# Altium schematic sheet Memory-CAN.SchDoc(Memory-CAN)
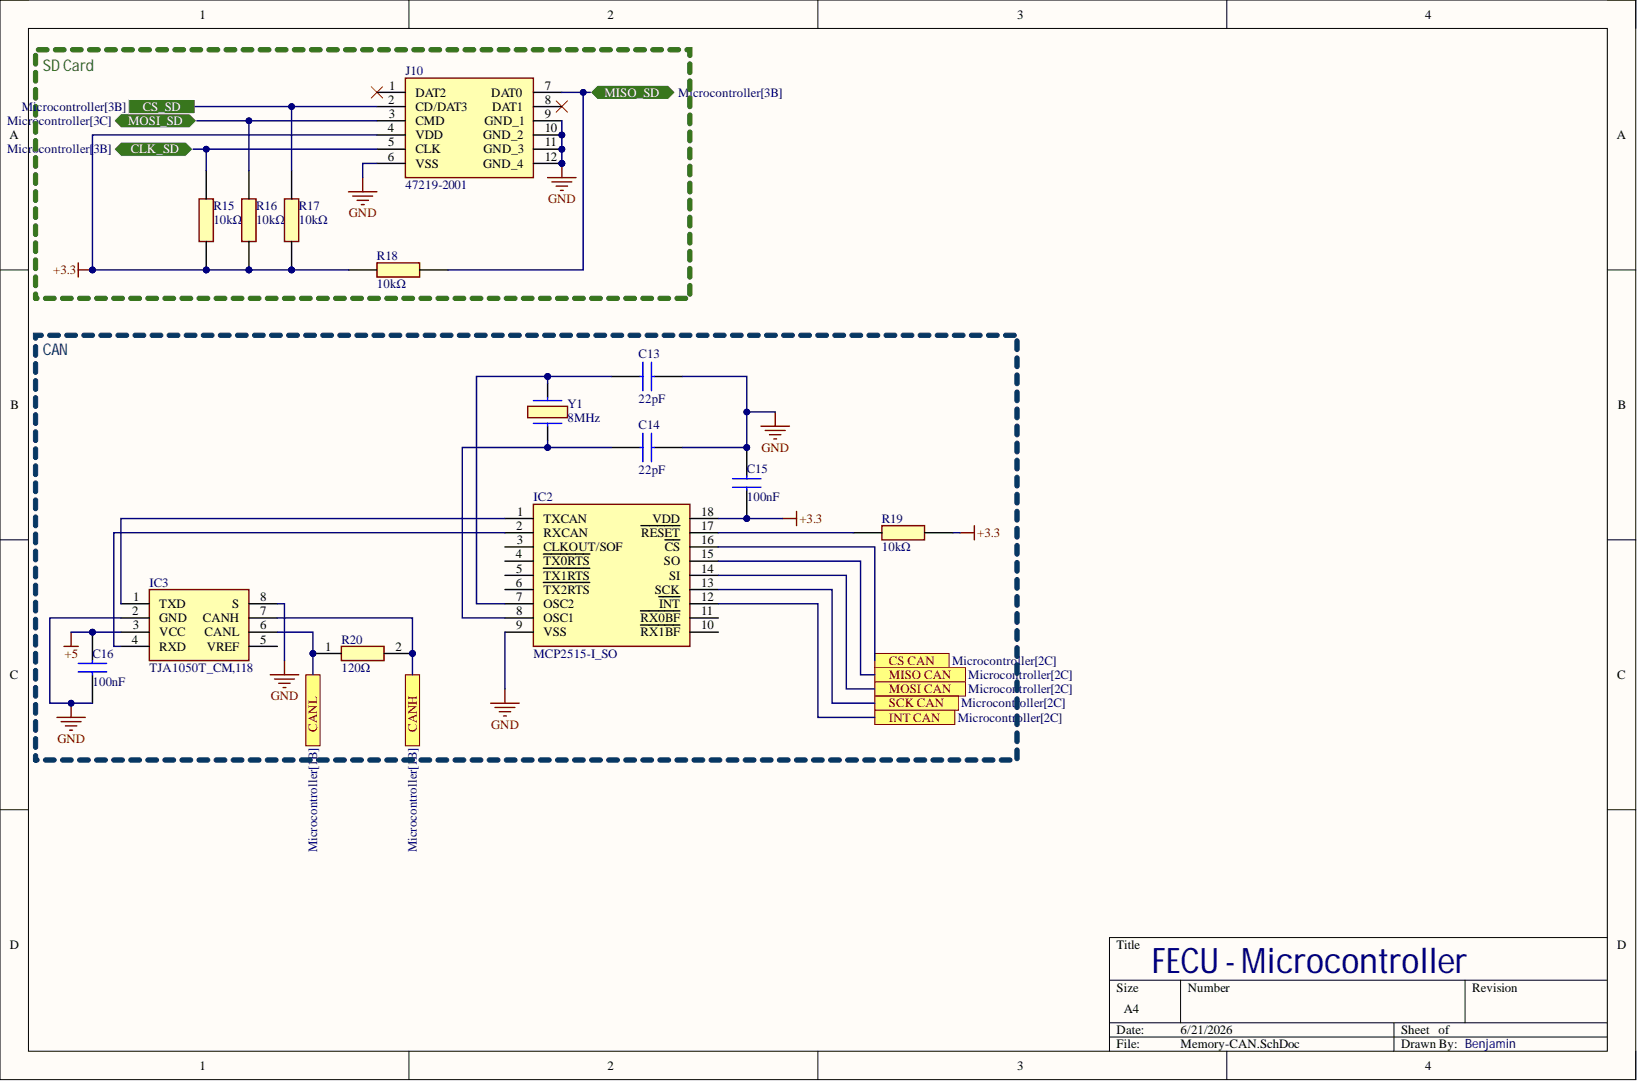
[diagram p0: Memory-CAN.SchDoc]

## Parts
C13  22pF
C14  22pF
C15  100nF
C16  100nF
IC2  MCP2515-I_SO
IC3  TJA1050T_CM,118
J10  47219-2001
R15  10kΩ
R16  10kΩ
R17  10kΩ
R18  10kΩ
R19  10kΩ
R20  120Ω
Y1  8MHz

## Ports
CANH, CANL, CLK_SD, CS CAN, CS_SD, INT CAN, MISO CAN, MISO_SD, MOSI CAN, MOSI_SD, SCK CAN

## Nets
+3.3: C15.2 IC2.18(VDD) J10.4(VDD) R15.1 R16.1 R17.1 R18.1 R19.2
+5: C16.1 IC3.3(VCC)
GND: C13.1 C14.1 C15.1 C16.2 IC2.9(VSS) IC3.2(GND) IC3.8(S) J10.6(VSS) J10.9(GND_1) J10.10(GND_2) J10.11(GND_3) J10.12(GND_4)
CANH: IC3.7(CANH) R20.2 ; port CANH
CANL: IC3.6(CANL) R20.1 ; port CANL
CLK_SD: J10.5(CLK) R15.2 ; port CLK_SD
CS CAN: IC2.16(CS) ; port CS CAN
CS_SD: J10.2(CD/DAT3) R17.2 ; port CS_SD
INT CAN: IC2.12(INT) ; port INT CAN
MISO CAN: IC2.15(SO) ; port MISO CAN
MISO_SD: J10.7(DAT0) R18.2 ; port MISO_SD
MOSI CAN: IC2.14(SI) ; port MOSI CAN
MOSI_SD: J10.3(CMD) R16.2 ; port MOSI_SD
SCK CAN: IC2.13(SCK) ; port SCK CAN
NetC13_2: C13.2 IC2.7(OSC2) Y1.
NetC14_2: C14.2 IC2.8(OSC1) Y1.2
NetIC2_1: IC2.1(TXCAN) IC3.1(TXD)
NetIC2_2: IC2.2(RXCAN) IC3.4(RXD)
NetIC2_17: IC2.17(RESET) R19.1

Not connected: IC2 3(CLKOUT/SOF) 4(TX0RTS) 5(TX1RTS) 6(TX2RTS) 10(RX1BF) 11(RX0BF); IC3 5(VREF); J10 1(DAT2) 8(DAT1)

## Library data of the parts
C13 C14 = 0805YA220JAT2A: Capacitor; Manufacturer_Name: Kyocera AVX; Component Kind: Standard; Manufacturer_Part_Number: 0805YA220JAT2A; Mouser Part Number: 581-0805YA220JAT2A; Mouser Price/Stock: https://www.mouser.co.uk/ProductDetail/KYOCERA-AVX/0805YA220JAT2A?qs=h45VL9SNQ1uLzsqNWWHBSQ%3D%3D
C15 C16 = CL21B104KBCNNNC: Capacitor; Manufacturer_Name: SAMSUNG; Arrow Part Number: CL21B104KBCNNNC; Arrow Price/Stock: https://www.arrow.com/en/products/cl21b104kbcnnnc/samsung-electro-mechanics?region=europe; Component Kind: Standard; Datasheet Link: https://datasheet.datasheetarchive.com/originals/distributors/Datasheets_SAMA/86a3eaf0882763ef32124d5901f9b833.pdf; Height: 0.95mm; Manufacturer_Part_Number: CL21B104KBCNNNC; Mouser Part Number: 187-CL21B104KBCNNNC; Mouser Price/Stock: https://www.mouser.co.uk/ProductDetail/Samsung-Electro-Mechanics/CL21B104KBCNNNC?qs=349EhDEZ59pEfpnm5yBEmQ%3D%3D
IC2 = MCP2515-I_SO: Integrated Circuit; Manufacturer_Name: Microchip; Manufacturer_Part_Number: MCP2515-I/SO; Arrow Part Number: MCP2515-I/SO; Arrow Price/Stock: https://www.arrow.com/en/products/mcp2515-iso/microchip-technology?utm_currency=USD&region=europe; Component Kind: Standard; Datasheet Link: https://datasheet.datasheetarchive.com/originals/distributors/Datasheets-34/DSA-675138.pdf; Height: 2.65mm; Mouser Part Number: 579-MCP2515-I/SO; Mouser Price/Stock: https://www.mouser.co.uk/ProductDetail/Microchip-Technology/MCP2515-I-SO?qs=KwArPi4cUogGDbGnphOvtQ%3D%3D
IC3 = TJA1050T_CM,118: Integrated Circuit; Manufacturer_Name: NXP; Manufacturer_Part_Number: TJA1050T/CM,118; Arrow Part Number: TJA1050T/CM,118; Arrow Price/Stock: https://www.arrow.com/en/products/tja1050tcm118/nxp-semiconductors?utm_currency=USD&region=nac; Component Kind: Standard; Datasheet Link: http://www.nxp.com/docs/en/data-sheet/TJA1050.pdf; Height: 1.75mm; Mouser Part Number: 771-TJA1050T/CM118; Mouser Price/Stock: https://www.mouser.co.uk/ProductDetail/NXP-Semiconductors/TJA1050T-CM118?qs=5XOdhvmYM0NFFspw0VP5sA%3D%3D
J10 = 47219-2001: Connector; Manufacturer_Name: Molex; Component Kind: Standard; Datasheet Link: http://www.molex.com/molex/products/datasheet.jsp?part=active/0472192001_MEMORY_CARD_SOCKET.xml&channel=Products&Lang=en-US; Manufacturer_Part_Number: 47219-2001; Mouser Part Number: 538-47219-2001; Mouser Price/Stock: https://www.mouser.co.uk/ProductDetail/Molex/47219-2001?qs=6kT3pPPHxnVaoXw6Dd7beQ%3D%3D
R15-R20 = RC0805FR-0710KL: Resistor; Manufacturer_Name: YAGEO; Arrow Part Number: RC0805FR-0710KL; Arrow Price/Stock: https://www.arrow.com/en/products/rc0805fr-0710kl/yageo?utm_currency=USD&region=europe; Component Kind: Standard; Datasheet Link: https://www.yageo.com/upload/media/product/productsearch/datasheet/rchip/PYu-RC_Group_51_RoHS_L_11.pdf; Height: 0.6mm; Manufacturer_Part_Number: RC0805FR-0710KL; Mouser Part Number: 603-RC0805FR-0710KL; Mouser Price/Stock: https://www.mouser.co.uk/ProductDetail/YAGEO/RC0805FR-0710KL?qs=7bY8808IzHq6vrU3BeiiYw%3D%3D
  R20: Library Name: SamacSys.SchLib
Y1 = XT9M20ANA8M: Crystal or Oscillator; Manufacturer_Name: Vishay; Arrow Part Number: XT9M20ANA8M; Arrow Price/Stock: https://www.arrow.com/en/products/xt9m20ana8m/vishay?utm_currency=USD&region=nac; Component Kind: Standard; Datasheet Link: https://componentsearchengine.com/Datasheets/2/XT9M20ANA8M.pdf; Height: 4.5mm; Manufacturer_Part_Number: XT9M20ANA8M; Mouser Part Number: 73-XT49M800-20; Mouser Price/Stock: https://www.mouser.co.uk/ProductDetail/Vishay-Dale/XT9M20ANA8M?qs=n8eVfDjedmFcUGyWy2y9%2FQ%3D%3D
